# Altium schematic sheet FT2232H_Efinix_Download_Cable_Ver1.0.SchDoc(FT2232H_Efinix_Download_Cable_Ver1.0)
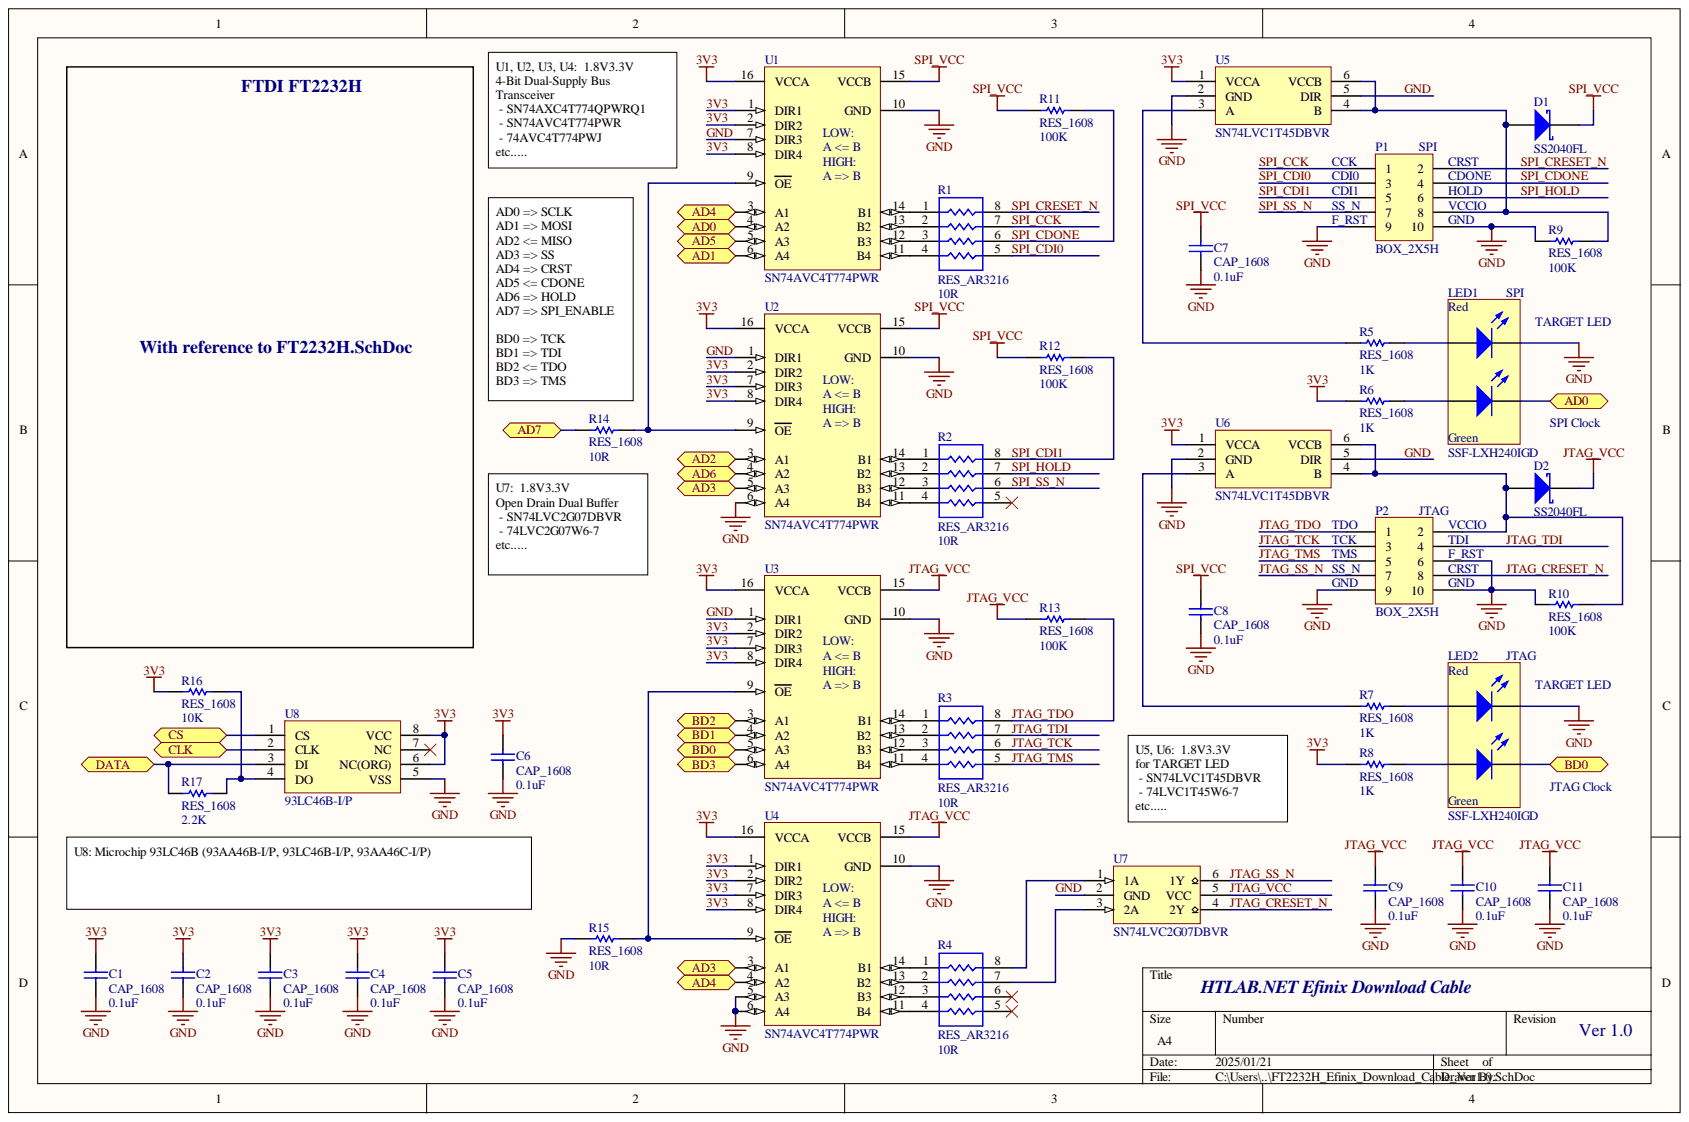
[diagram p0: FT2232H_Efinix_Download_Cable_Ver1.0.SchDoc]

## Parts
C1  CAP_1608  value 0.1uF  [C1608_N]
C2  CAP_1608  value 0.1uF  [C1608_N]
C3  CAP_1608  value 0.1uF  [C1608_N]
C4  CAP_1608  value 0.1uF  [C1608_N]
C5  CAP_1608  value 0.1uF  [C1608_N]
C6  CAP_1608  value 0.1uF  [C1608_N]
C7  CAP_1608  value 0.1uF  [C1608_N]
C8  CAP_1608  value 0.1uF  [C1608_N]
C9  CAP_1608  value 0.1uF  [C1608_N]
C10  CAP_1608  value 0.1uF  [C1608_N]
C11  CAP_1608  value 0.1uF  [C1608_N]
D1  SS2040FL  [SOD-123F_N]
D2  SS2040FL  [SOD-123F_N]
LED1  SSF-LXH240IGD  [SSF-LXH240IGD]
LED2  SSF-LXH240IGD  [SSF-LXH240IGD]
P1  BOX_2X5H  [BOXH_2X5_HORIZONTAL_N]
P2  BOX_2X5H  [BOXH_2X5_HORIZONTAL_N]
R1  RES_AR3216  value 10R  [AR8_3216_N]
R2  RES_AR3216  value 10R  [AR8_3216_N]
R3  RES_AR3216  value 10R  [AR8_3216_N]
R4  RES_AR3216  value 10R  [AR8_3216_N]
R5  RES_1608  value 1K  [R1608_N]
R6  RES_1608  value 1K  [R1608_N]
R7  RES_1608  value 1K  [R1608_N]
R8  RES_1608  value 1K  [R1608_N]
R9  RES_1608  value 100K  [R1608_N]
R10  RES_1608  value 100K  [R1608_N]
R11  RES_1608  value 100K  [R1608_N]
R12  RES_1608  value 100K  [R1608_N]
R13  RES_1608  value 100K  [R1608_N]
R14  RES_1608  value 10R  [R1608_N]
R15  RES_1608  value 10R  [R1608_N]
R16  RES_1608  value 10K  [R1608_N]
R17  RES_1608  value 2.2K  [R1608_N]
U1  SN74AVC4T774PWR  [TSSOP-16_N]
U2  SN74AVC4T774PWR  [TSSOP-16_N]
U3  SN74AVC4T774PWR  [TSSOP-16_N]
U4  SN74AVC4T774PWR  [TSSOP-16_N]
U5  SN74LVC1T45DBVR  [SOT-23-6_N]
U6  SN74LVC1T45DBVR  [SOT-23-6_N]
U7  SN74LVC2G07DBVR  [SOT-23-6_N]
U8  93LC46B-I/P  [DIP-8_300]

## Ports
AD0, AD1, AD2, AD3, AD4, AD5, AD6, AD7, BD0, BD1, BD2, BD3, CLK, CS, DATA

## Nets
SPI_VCC: C7.2 C8.2 D1.1 R11.1 R12.1 U1.15(VCCB) U2.15(VCCB)
3V3: C1.2 C2.2 C3.2 C4.2 C5.2 C6.2 R6.1 R8.1 R16.1 U1.1(DIR1) U1.2(DIR2) U1.8(DIR4) U1.16(VCCA) U2.2(DIR2) U2.7(DIR3) U2.8(DIR4) U2.16(VCCA) U3.2(DIR2) U3.7(DIR3) U3.8(DIR4) U3.16(VCCA) U4.1(DIR1) U4.2(DIR2) U4.7(DIR3) U4.8(DIR4) U4.16(VCCA) U5.1(VCCA) U6.1(VCCA) U8.6(NC(ORG)) U8.8(VCC)
AD0: LED1.3 U1.4(A2) ; port AD0
AD1: U1.6(A4) ; port AD1
AD2: U2.3(A1) ; port AD2
AD3: U2.5(A3) U4.3(A1) ; port AD3
AD4: U1.3(A1) U4.4(A2) ; port AD4
AD5: U1.5(A3) ; port AD5
AD6: U2.4(A2) ; port AD6
AD7: R14.1 ; port AD7
BD0: LED2.3 U3.5(A3) ; port BD0
BD1: U3.4(A2) ; port BD1
BD2: U3.3(A1) ; port BD2
BD3: U3.6(A4) ; port BD3
CLK: U8.2(CLK) ; port CLK
CS: U8.1(CS) ; port CS
DATA: R17.1 U8.3(DI) ; port DATA
GND: C1.1 C2.1 C3.1 C4.1 C5.1 C6.1 C7.1 C8.1 C9.1 C10.1 C11.1 LED1.1 LED2.1 P1.9 P1.10 P2.6 P2.9 P2.10 R9.1 R10.1 R15.1 U1.7(DIR3) U1.10(GND) U2.1(DIR1) U2.6(A4) U2.10(GND) U3.1(DIR1) U3.10(GND) U4.5(A3) U4.6(A4) U4.10(GND) U5.2(GND) U5.5(DIR) U6.2(GND) U6.5(DIR) U7.2(GND) U8.5(VSS)
JTAG_CRESET_N: P2.8 U7.4(2Y)
JTAG_SS_N: P2.7 U7.6(1Y)
JTAG_TCK: P2.3 R3.6
JTAG_TDI: P2.4 R3.7
JTAG_TDO: P2.1 R3.8 R13.2
JTAG_TMS: P2.5 R3.5
JTAG_VCC: C9.2 C10.2 C11.2 D2.1 R13.1 U3.15(VCCB) U4.15(VCCB) U7.5(VCC)
SPI_CCK: P1.1 R1.7
SPI_CDI0: P1.3 R1.5
SPI_CDI1: P1.5 R2.8 R12.2
SPI_CDONE: P1.4 R1.6 R11.2
SPI_CRESET_N: P1.2 R1.8
SPI_HOLD: P1.6 R2.7
SPI_SS_N: P1.7 R2.6
NetD1_2: D1.2 P1.8 R9.2 U5.4(B) U5.6(VCCB)
NetD2_2: D2.2 P2.2 R10.2 U6.4(B) U6.6(VCCB)
NetLED1_2: LED1.2 R5.2
NetLED1_4: LED1.4 R6.2
NetLED2_2: LED2.2 R7.2
NetLED2_4: LED2.4 R8.2
NetR1_1: R1.1 U1.14(B1)
NetR1_2: R1.2 U1.13(B2)
NetR1_3: R1.3 U1.12(B3)
NetR1_4: R1.4 U1.11(B4)
NetR2_1: R2.1 U2.14(B1)
NetR2_2: R2.2 U2.13(B2)
NetR2_3: R2.3 U2.12(B3)
NetR2_4: R2.4 U2.11(B4)
NetR3_1: R3.1 U3.14(B1)
NetR3_2: R3.2 U3.13(B2)
NetR3_3: R3.3 U3.12(B3)
NetR3_4: R3.4 U3.11(B4)
NetR4_1: R4.1 U4.14(B1)
NetR4_2: R4.2 U4.13(B2)
NetR4_3: R4.3 U4.12(B3)
NetR4_4: R4.4 U4.11(B4)
NetR4_7: R4.7 U7.3(2A)
NetR4_8: R4.8 U7.1(1A)
NetR5_1: R5.1 U5.3(A)
NetR7_1: R7.1 U6.3(A)
NetR14_2: R14.2 U1.9(OE) U2.9(OE)
NetR15_2: R15.2 U3.9(OE) U4.9(OE)
NetR16_2: R16.2 R17.2 U8.4(DO)

Not connected: R2 5; R4 5 6; U8 7(NC)

## Library data of the parts
C1-C11 = CAP_1608: Capacitor 1608; Published: 8-Jun-2000; PackageReference: C1206; PackageDescription: Chip Capacitor; Library Name: Capacitor.IntLib; LatestRevisionNote: IPC-7351 Footprint Added.; LatestRevisionDate: 29-May-2009; Component Kind: Standard; Publisher: Altium Limited
D1 D2 = SS2040FL: Schottky Rectifier SOD-123FL; Note: Terminal 2 is the TAB and is electrically connected to the cathode; Published: 8-Jun-2000; PackageVersion: Feb-1998; PackageReference: SOD-123FL; PackageDescription: TO, Thru-Hole, Vertical, Heatsink Mounted; 3 In-Line Leads (1 Absent); Pitch 2.54 mm; Library Name: Diode.IntLib; LatestRevisionNote: Re-released for DXP Platform.; LatestRevisionDate: 17-Jul-2002; DatasheetVersion: PD-2.057B; ComponentLink1URL: http://www.irf.com/; ComponentLink1Description: Manufacturer Link; Component Kind: Standard; Publisher: Altium Limited
LED1 LED2 = SSF-LXH240IGD: SSF-LXH240IGD, MHK2396EGBTD, 5530112F, WP934MD/LILGD, H-201C, LED-322; Published: 8-Jun-2000; PackageReference: LED-0; PackageDescription: LED; 2 Leads; Library Name: Optical.IntLib; LatestRevisionNote: Mech Layer 1 removed.; LatestRevisionDate: 08-Jul-2005; Component Kind: Standard; Publisher: Altium Limited
P1 P2 = BOX_2X5H: Header, 5-Pin, Dual row; Library Name: Connector.IntLib; Component Kind: Standard
R1-R4 = RES_AR3216: Resistor Array, CAT16-J4 / EXB-V8V; Library Name: Resistor.IntLib; Component Kind: Standard
R5 R7 = RES_1608: Resistor 1608; Value: 1K; Published: 8-Jun-2000; PackageDescription: Chip Resistor; Library Name: Resistor.IntLib; LatestRevisionNote: Re-released for DXP Platform.; LatestRevisionDate: 17-Jul-2002; Component Kind: Standard; Publisher: Altium Limited
R6 R8-R17 = RES_1608: Resistor 1608; Published: 8-Jun-2000; PackageDescription: Chip Resistor; Library Name: Resistor.IntLib; LatestRevisionNote: Re-released for DXP Platform.; LatestRevisionDate: 17-Jul-2002; Component Kind: Standard; Publisher: Altium Limited
U1-U4 = SN74AVC4T774PWR: 4-Bit Dual-Supply Bus Transceiver With Configurable Voltage-Level  Shifting and 3-State Outputs With Independent Direction Control Inputs; Published: 8-Jun-2000; PackageVersion: Feb-1999; PackageDescription: 16-Pin Thin Small Outline Package 0.65 mm Pitch; Library Name: Logic.IntLib; LatestRevisionDate: 16-Oct-2006; DatasheetVersion: Apr-1999; ComponentLink2Description: Datasheet; ComponentLink1URL: http://www.ti.com/; ComponentLink1Description: Manufacturer Link; Component Kind: Standard; Publisher: Altium Limited
U5 U6 = SN74LVC1T45DBVR: Single-Bit Dual-Supply Bus Transceiver With Configurable Voltage  Translation and 3-State Outputs; Library Name: Logic.IntLib; LatestRevisionNote: Re-released for DXP Platform.; LatestRevisionDate: 17-Jul-2002; Component Kind: Standard; Publisher: Altium Limited
U7 = SN74LVC2G07DBVR: Dual Buffer and Driver With Open-Drain Outputs; Published: 8-Jun-2000; PackageVersion: 1998; Library Name: Logic.IntLib; LatestRevisionNote: Re-released for DXP Platform.; LatestRevisionDate: 17-Jul-2002; DatasheetVersion: May-1986; ComponentLink1URL: http://www.ti.com/; ComponentLink1Description: Manufacturer Link; Component Kind: Standard; Publisher: Altium Limited
U8 = 93LC46B-I/P: 1Kb Microwire Serial EEPROM with 16-Bit Organization; Library Name: Memory.IntLib; LatestRevisionNote: Re-released for DXP Platform.; LatestRevisionDate: 17-Jul-2002; Component Kind: Standard; Publisher: Altium Limited
